# Altium schematic sheet sbcp-master.SchDoc
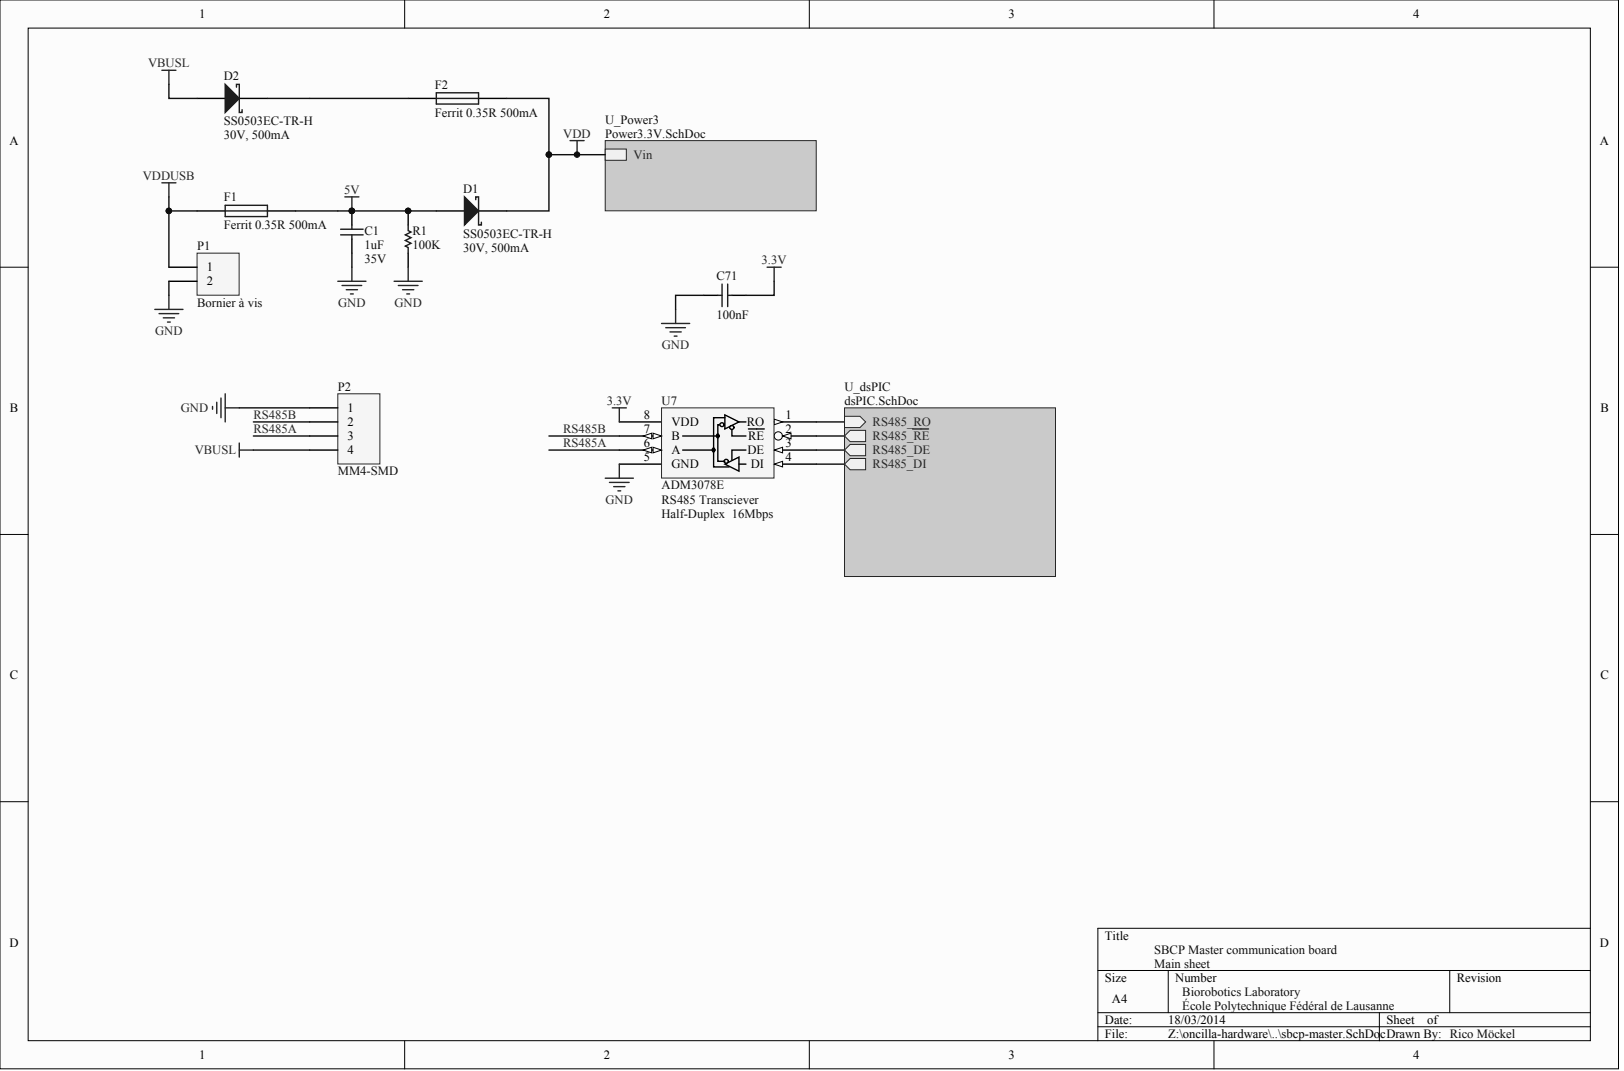
[diagram p0: sbcp-master.SchDoc]

## Parts
C1  value 1uF  Voltage: 35V  (hidden comment: 1uF, 35V)
C71  100nF
D1  SS0503EC-TR-H  Values: 30V, 500mA
D2  SS0503EC-TR-H  Values: 30V, 500mA
F1  Ferrit 0.35R 500mA
F2  Ferrit 0.35R 500mA
P1  Bornier à vis
P2  MM4-SMD
R1  100K
U7  ADM3078E

## Nets
3.3V: C71.1 U7.8(VDD)
5V: C1.2 D1.1 F1.2 R1.1
GND: C1.1 C71.2 P1.2 P2.1 R1.2 U7.5(GND)
VBUSL: D2.1 P2.4
VDD: D1.2 F2.2
VDDUSB: F1.1 P1.1
RS485A: P2.3 U7.6(A)
RS485B: P2.2 U7.7(B)
NetD2_2: D2.2 F2.1
NetU7_1: U7.1(RO)
NetU7_2: U7.2(RE)
NetU7_3: U7.3(DE)
NetU7_4: U7.4(DI)

## Library data of the parts
C1 C71 D1 D2 F1 F2 P1 P2 R1 U7 = (no symbol name)
  C1: Published: 8-Jun-2000; LatestRevisionDate: 17-Jul-2002; LatestRevisionNote: Re-released for DXP Platform.; PackageReference: 0603
  C71: Published: 8-Jun-2000; LatestRevisionDate: 17-Jul-2002; LatestRevisionNote: Re-released for DXP Platform.; PackageReference: RAD-0.3; Value: 100nF
  D1 D2: Published: 8-Jun-2000; LatestRevisionDate: 17-Jul-2002; LatestRevisionNote: Re-released for DXP Platform.; PackageReference: SMB; Code_JEDEC: DO-214-AA
  F1 F2: Published: 8-Jun-2000; LatestRevisionDate: 17-Jul-2002; LatestRevisionNote: Re-released for DXP Platform.; PackageReference: PIN-W2/E2.8
  P1 P2: LatestRevisionDate: 17-Jul-2002; LatestRevisionNote: Re-released for DXP Platform.
  R1: Published: 8-Jun-2000; LatestRevisionDate: 17-Jul-2002; LatestRevisionNote: Re-released for DXP Platform.; PackageReference: AXIAL-0.3
  C1 C71 D1 D2 F1 F2 P1 P2 R1: Publisher: Altium Limited
